# Altium schematic sheet Beechat_SCH_REV1.0.SchDoc
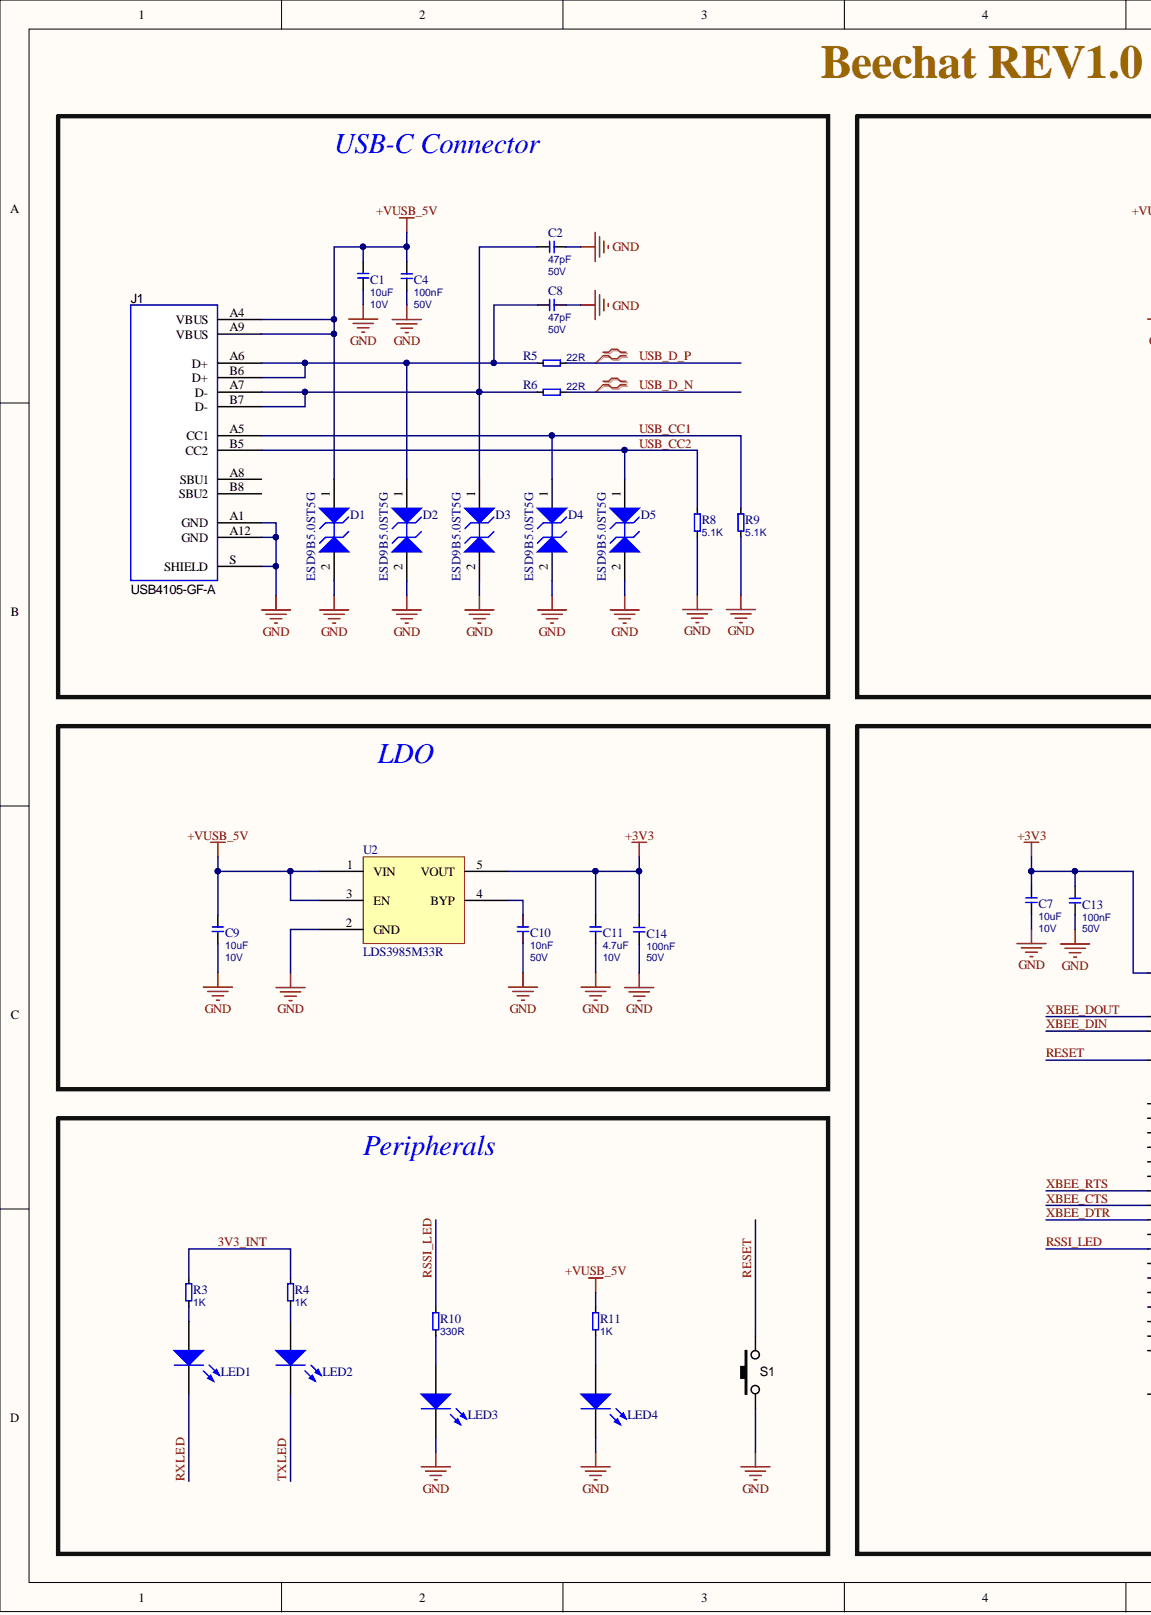
[diagram p0: Beechat_SCH_REV1.0.SchDoc, left]
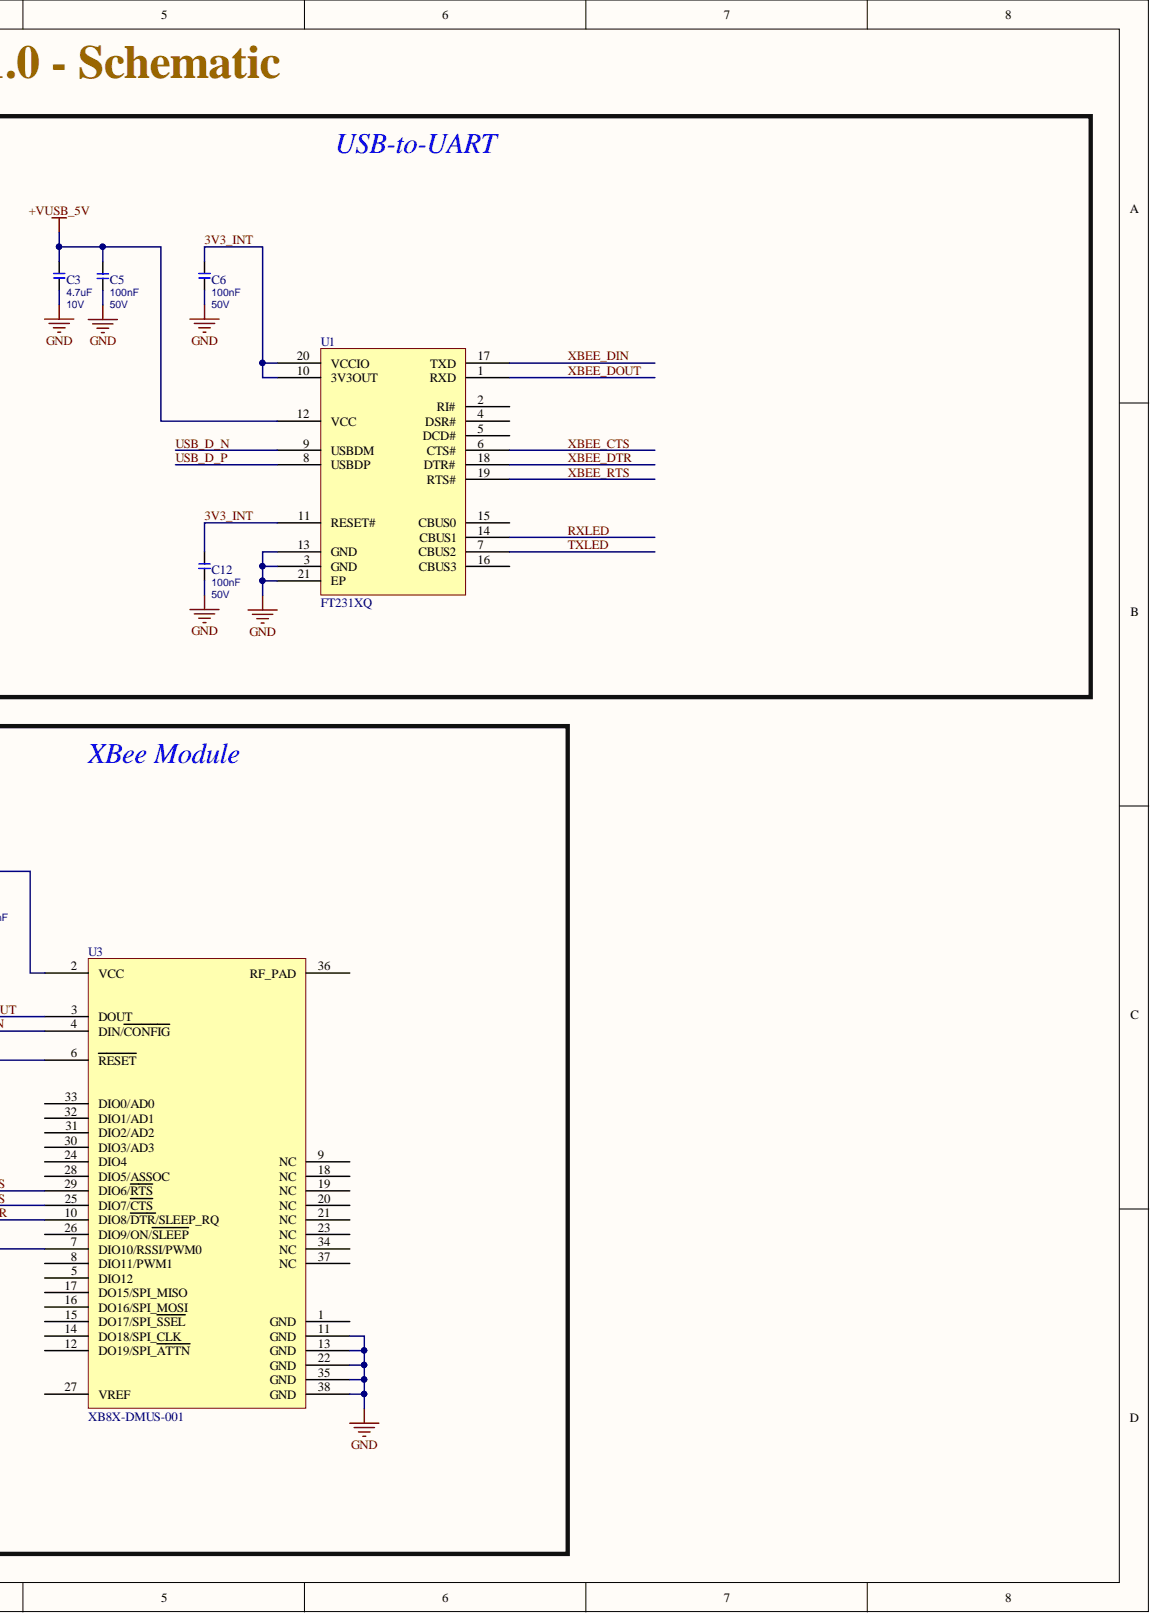
[diagram p1: Beechat_SCH_REV1.0.SchDoc, right]

## Parts
C1  10uF  Rating: 10V  [CAP0603]
C2  47pF  [CAP0402]
C3  4.7uF  Rating: 10V  [CAP0603]
C4  100nF  Rating: 50V  [CAP0402]
C5  100nF  Rating: 50V  [CAP0402]
C6  100nF  Rating: 50V  [CAP0402]
C7  10uF  Rating: 10V  [CAP0603]
C8  47pF  Rating: 50V  [CAP0402]
C9  10uF  Rating: 10V  [CAP0603]
C10  10nF  Rating: 50V  [CAP0402]
C11  4.7uF  Rating: 10V  [CAP0603]
C12  100nF  Rating: 50V  [CAP0402]
C13  100nF  Rating: 50V  [CAP0402]
C14  100nF  Rating: 50V  [CAP0402]
D1  ESD9B5.0ST5G  [SOD-923]
D2  ESD9B5.0ST5G  [SOD-923]
D3  ESD9B5.0ST5G  [SOD-923]
D4  ESD9B5.0ST5G  [SOD-923]
D5  ESD9B5.0ST5G  [SOD-923]
J1  USB4105-GF-A  [USB4105-GF-A]
LED1  LED_0402  [Led-0402]  (value not drawn)
LED2  LED_0402  [Led-0402]  (value not drawn)
LED3  LED_0402  [Led-0402]  (value not drawn)
LED4  LED_0402  [Led-0402]  (value not drawn)
R3  1K  [RES0402]
R4  1K  [RES0402]
R5  22R  [RES0402]
R6  22R  [RES0402]
R8  5.1K  [RES0402]
R9  5.1K  [RES0402]
R10  330R  [RES0402]
R11  1K  [RES0402]
S1  8-1437565-1  [8-1437565-1]  (value not drawn)
U1  FT231XQ  [QFN-20]
U2  LDS3985M33R  [SOT23-5]
U3  XB8X-DMUS-001  [XB8X-DMUS-001]

## Nets
+3V3: C7.2 C11.2 C13.2 C14.2 U2.5(VOUT) U3.2(VCC)
+VUSB_5V: C1.2 C3.2 C4.2 C5.2 C9.2 D1.1 J1.A4(VBUS) J1.A9(VBUS) R11.2 U1.12(VCC) U2.1(VIN) U2.3(EN)
GND: C1.1 C2.2 C3.1 C4.1 C5.1 C6.1 C7.1 C8.2 C9.1 C10.1 C11.1 C12.1 C13.1 C14.1 D1.2 D2.2 D3.2 D4.2 D5.2 J1.A1(GND) J1.A12(GND) J1.S(SHIELD) LED3.2 LED4.2 R8.1 R9.1 S1.1 U1.3(GND) U1.13(GND) U1.21(EP) U2.2(GND) U3.11(GND) U3.13(GND) U3.22(GND) U3.35(GND) U3.38(GND)
3V3_INT: C6.2 C12.2 R3.2 R4.2 U1.10(3V3OUT) U1.11(RESET#) U1.20(VCCIO)
RESET: S1.2 U3.6(RESET)
RSSI_LED: R10.2 U3.7(DIO10/RSSI/PWM0)
RXLED: LED1.2 U1.14(CBUS1)
TXLED: LED2.2 U1.7(CBUS2)
USB_CC1: D4.1 J1.A5(CC1) R9.2
USB_CC2: D5.1 J1.B5(CC2) R8.2
USB_D_N: R6.2 U1.9(USBDM)
USB_D_P: R5.2 U1.8(USBDP)
XBEE_CTS: U1.6(CTS#) U3.25(DIO7/CTS)
XBEE_DIN: U1.17(TXD) U3.4(DIN/CONFIG)
XBEE_DOUT: U1.1(RXD) U3.3(DOUT)
XBEE_DTR: U1.18(DTR#) U3.10(DIO8/DTR/SLEEP_RQ)
XBEE_RTS: U1.19(RTS#) U3.29(DIO6/RTS)
NetC2_1: C2.1 D3.1 J1.A7(D-) J1.B7(D-) R6.1
NetC8_1: C8.1 D2.1 J1.A6(D+) J1.B6(D+) R5.1
NetC10_2: C10.2 U2.4(BYP)
NetLED1_1: LED1.1 R3.1
NetLED2_1: LED2.1 R4.1
NetLED3_1: LED3.1 R10.1
NetLED4_1: LED4.1 R11.1

Not connected: J1 A8(SBU1) B8(SBU2); U1 2(RI#) 4(DSR#) 5(DCD#) 15(CBUS0) 16(CBUS3); U3 1(GND) 5(DIO12) 8(DIO11/PWM1) 9(NC) 12(DO19/SPI_ATTN) 14(DO18/SPI_CLK) 15(DO17/SPI_SSEL) 16(DO16/SPI_MOSI) 17(DO15/SPI_MISO) 18(NC) 19(NC) 20(NC) 21(NC) 23(NC) 24(DIO4) 26(DIO9/ON/SLEEP) 27(VREF) 28(DIO5/ASSOC) 30(DIO3/AD3) 31(DIO2/AD2) 32(DIO1/AD1) 33(DIO0/AD0) 34(NC) 36(RF_PAD) 37(NC)

## Library data of the parts
C1 C3 C7 C9 C11 = CAP_0603: Capacitor Ceramic 0603; Tolerance: 10%; Component Kind: Standard; Library Name: Lamp_LIB.SchLib
  C1 C7 C9: Value: 10uF
  C3 C11: Value: 4.7uF
C2 = CAP_0402: Capacitor Ceramic 0402; Rating: 50V; Tolerance: 10%; Component Kind: Standard; Value: 47pF; Library Name: Lamp_LIB.SchLib
C4-C6 C8 C10 C12-C14 = CAP_0402: Capacitor Ceramic 0402; Tolerance: 10%; Component Kind: Standard; Library Name: Lamp_LIB.SchLib
  C4-C6 C12-C14: Value: 100nF
  C8: Value: 47pF
  C10: Value: 10nF
D1-D5 = ESD9B5.0ST5G: TVS DIODE 5V SOD923; Component Kind: Standard; Library Name: Lamp_LIB.SchLib
J1 = USB4105-GF-A: USB SHIELDED I/O RECP TYPE C; Component Kind: Standard; Library Name: Lamp_LIB.SchLib
LED1-LED4 = LED_0402: SMD LED; Component Kind: Standard; Library Name: Lamp_LIB.SchLib
R3-R6 R8-R11 = R_0402: Resistor SMD 0402; Tolerance: 1%; Component Kind: Standard; Library Name: Lamp_LIB.SchLib
  R3 R4 R11: Value: 1K
  R5 R6: Value: 22R
  R8 R9: Value: 5.1K
  R10: Value: 330R
S1 = 8-1437565-1: SWITCH TACTILE SPST-NO 0.02A 15V; Component Kind: Standard; Library Name: Lamp_LIB.SchLib
U1 = FT231XQ: IC USB SERIAL FULL UART 20QFN; Component Kind: Standard; Library Name: Lamp_LIB.SchLib
U2 = LDS3985M33R: IC REG LINEAR 3.3V 300MA SOT23-5; Component Kind: Standard; Library Name: Lamp_LIB.SchLib
U3 = XB8X-DMUS-001: RX TXRX MOD ISM < 1GHZ U.FL SMD; Component Kind: Standard; Library Name: Lamp_LIB.SchLib
